# Altium schematic sheet Main Board-brushless_cmd-v2.0.0.SchDoc("Main Board-brushless_cmd-v2.0.0")
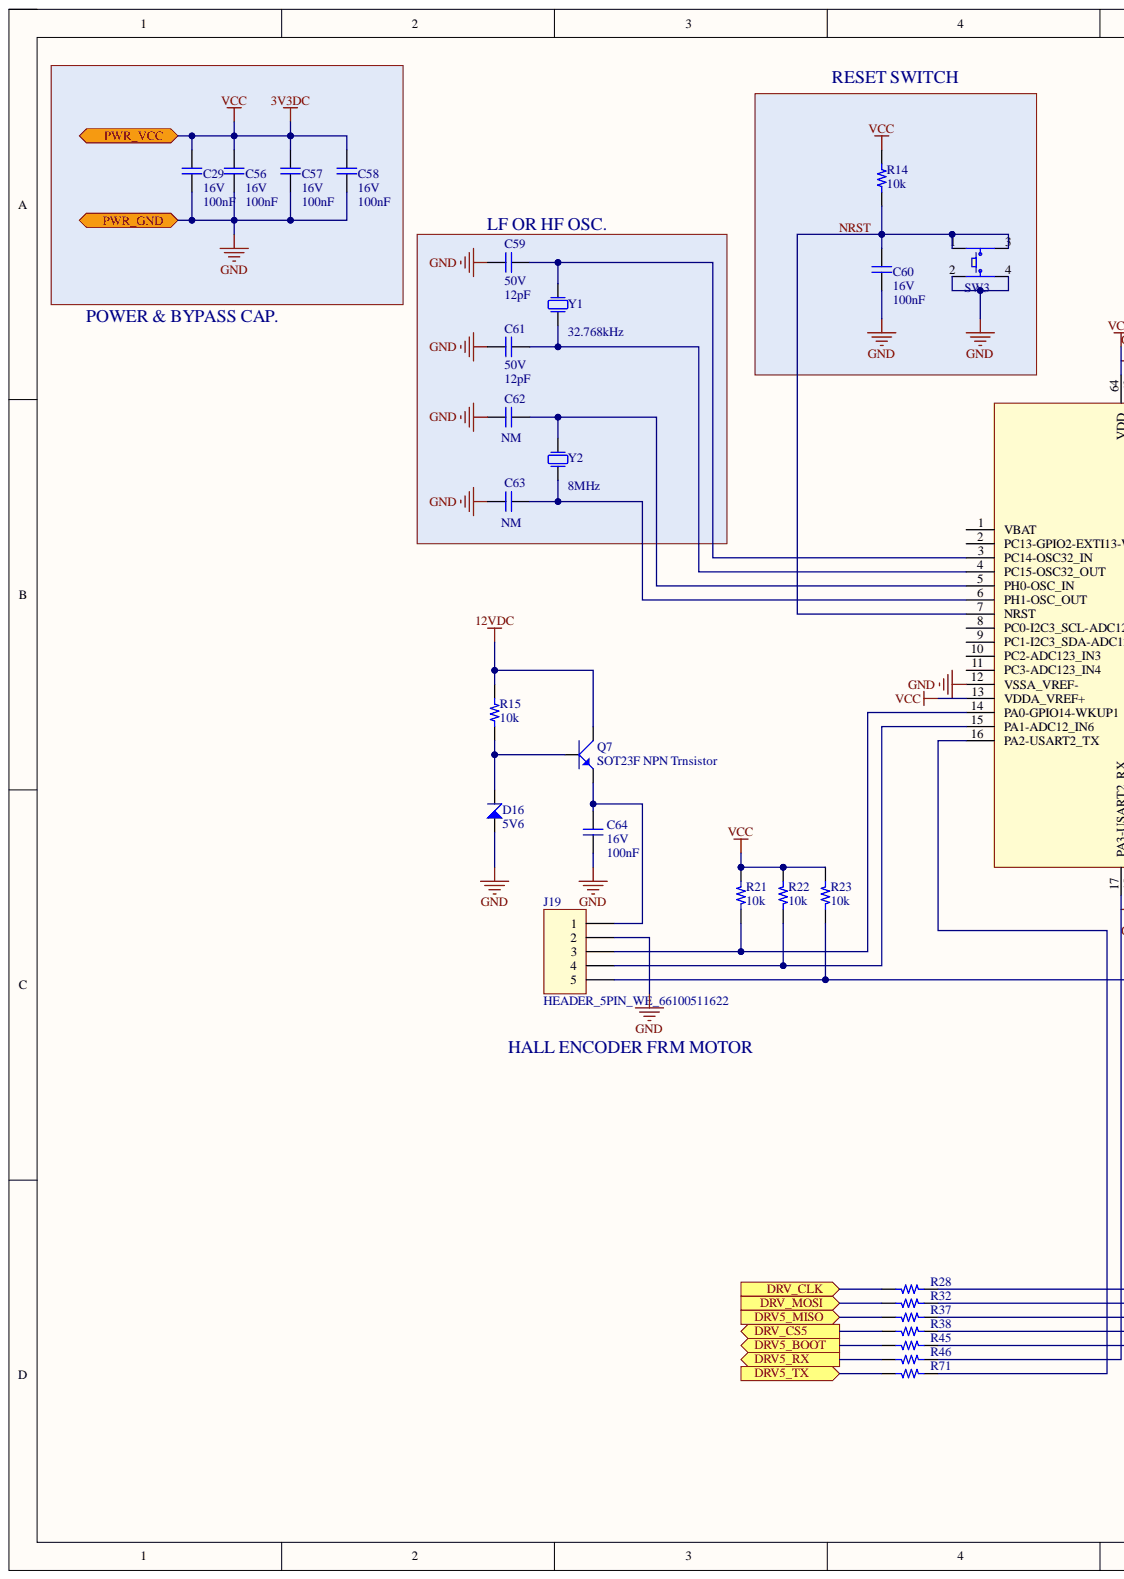
[diagram p0: Main Board-brushless_cmd-v2.0.0.SchDoc, left]
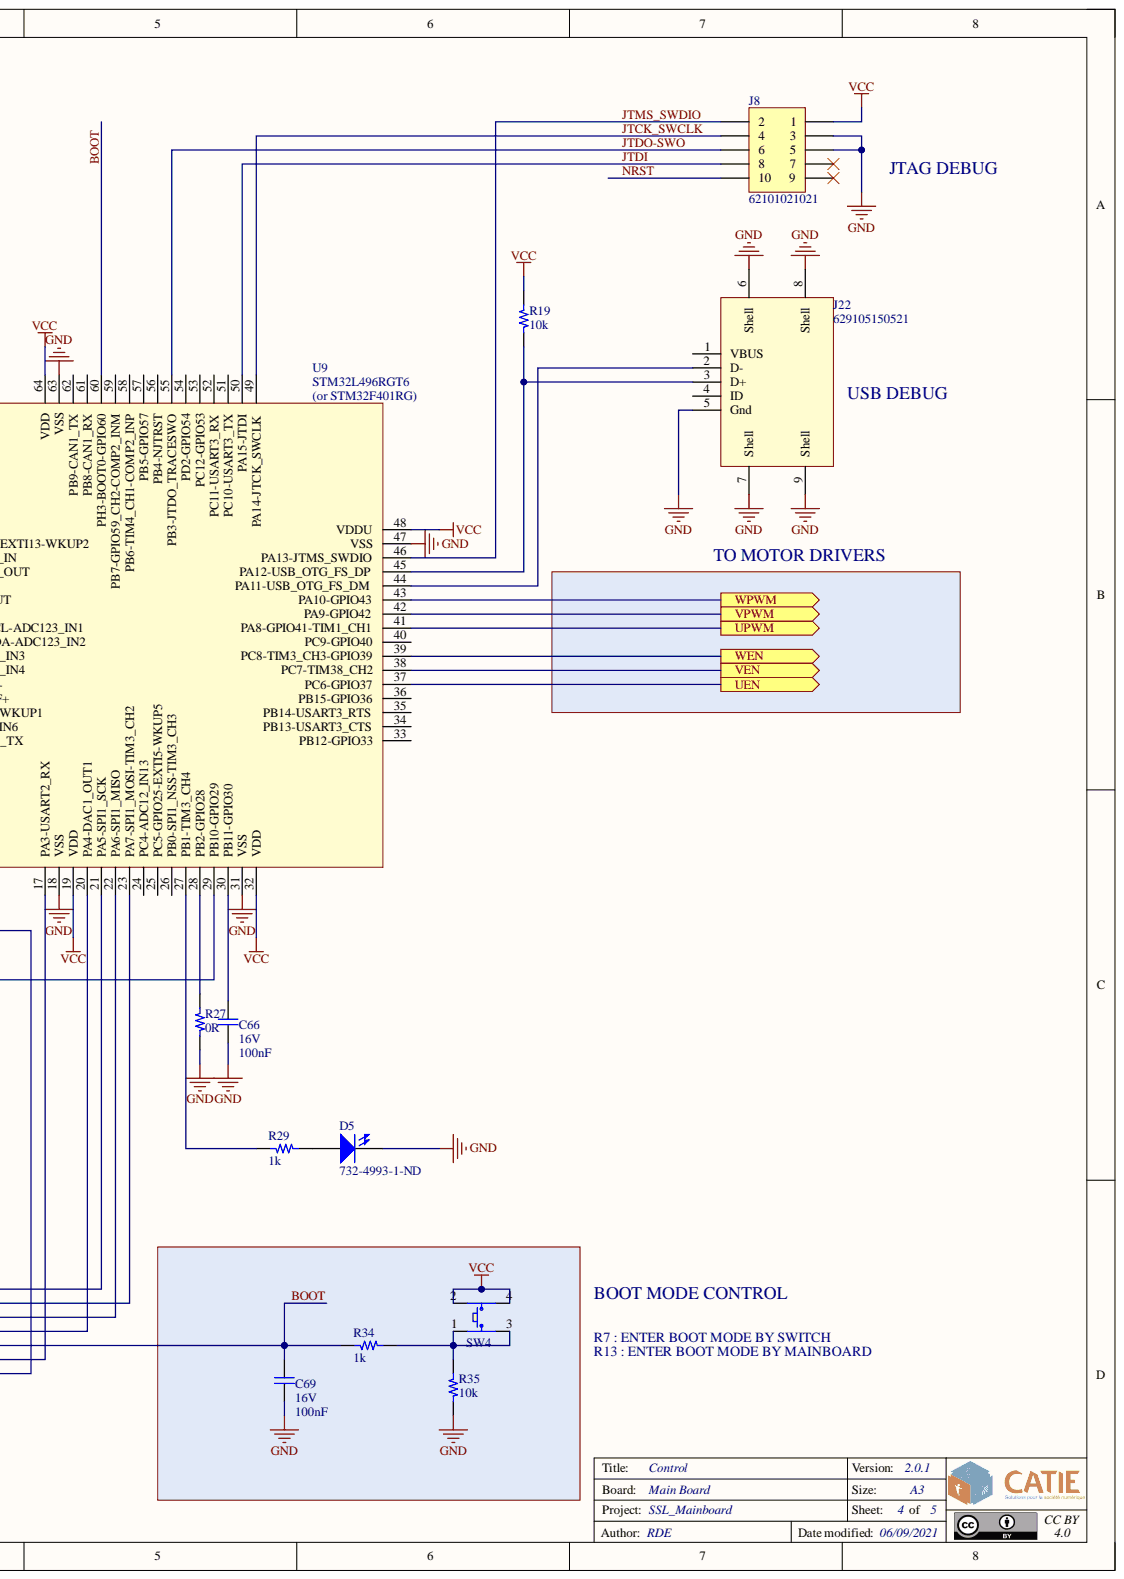
[diagram p1: Main Board-brushless_cmd-v2.0.0.SchDoc, right]

## Parts
C29  value 100nF  Rating: 16V  [CAP_CER_0603]  (hidden comment: CAP_CER_0603)
C56  value 100nF  Rating: 16V  [CAP_CER_0603]  (hidden comment: CAP_CER_0603)
C57  value 100nF  Rating: 16V  [CAP_CER_0603]  (hidden comment: CAP_CER_0603)
C58  value 100nF  Rating: 16V  [CAP_CER_0603]  (hidden comment: CAP_CER_0603)
C59  value 12pF  Rating: 50V  [CAP_CER_0603]  (hidden comment: CAP_CER_0603)
C60  value 100nF  Rating: 16V  [CAP_CER_0603]  (hidden comment: CAP_CER_0603)
C61  value 12pF  Rating: 50V  [CAP_CER_0603]  (hidden comment: CAP_CER_0603)
C62  value NM  [CAP_CER_0402]  (hidden comment: CAP_CER_0402)
C63  value NM  [CAP_CER_0402]  (hidden comment: CAP_CER_0402)
C64  value 100nF  Rating: 16V  [CAP_CER_0603]  (hidden comment: CAP_CER_0603)
C66  value 100nF  Rating: 16V  [CAP_CER_0603]  (hidden comment: CAP_CER_0603)
C69  value 100nF  Rating: 16V  [CAP_CER_0603]  (hidden comment: CAP_CER_0603)
D5  Supplier Part Number 1: 732-4993-1-ND  [DIODE_LED_1206_TOP]  (hidden comment: LED SMD 1206 Top view)
D16  value 5V6  [DIODE_ZENER_BZD27C-SERIES_DO219-AB]  (hidden comment: DIODE_ZENER_BZD27C-SERIES_DO219-AB)
J8  Reference: 62101021021  [HEADER_WURTH_62101021021_10PIN]  (hidden comment: HEADER_WURTH_62101021021)
J19  HEADER_5PIN_WE_66100511622  [66100511622]
J22  Reference: 629105150521  [HEADER_MICROUSB_629105150521]  (hidden comment: HEADER_MICROUSB_629105150521)
Q7  SOT23F NPN Trnsistor  [TR_SOT23]
R14  value 10k  [RES_0603]  (hidden comment: RES_0603)
R15  value 10k  [RES_0603]  (hidden comment: RES_0603)
R19  value 10k  [RES_0603]  (hidden comment: RES_0603)
R21  value 10k  [RES_0603]  (hidden comment: RES_0603)
R22  value 10k  [RES_0603]  (hidden comment: RES_0603)
R23  value 10k  [RES_0603]  (hidden comment: RES_0603)
R27  value 0R  [RES_0603]  (hidden comment: RES_0603)
R28  RES_0603  [RES_0603]  (value not drawn)
R29  value 1k  [RES_0603]  (hidden comment: RES_0603)
R32  RES_0603  [RES_0603]  (value not drawn)
R34  value 1k  [RES_0603]  (hidden comment: RES_0603)
R35  value 10k  [RES_0603]  (hidden comment: RES_0603)
R37  RES_0603  [RES_0603]  (value not drawn)
R38  RES_0603  [RES_0603]  (value not drawn)
R45  RES_0603  [RES_0603]  (value not drawn)
R46  RES_0603  [RES_0603]  (value not drawn)
R71  RES_0603  [RES_0603]  (value not drawn)
SW3  SW_TACT_MICRO_SIDE_WE_434331045822  [SW_TACT_MICRO_SIDE_WE_434331045822]  (value not drawn)
SW4  SW_TACT_MICRO_SIDE_WE_434331045822  [SW_TACT_MICRO_SIDE_WE_434331045822]  (value not drawn)
U9  Reference: STM32L496RGT6  [IC_STM32_LQFP64]  (hidden comment: IC_STM32L496RXTX_LQFP64)
Y1  value 32.768kHz  [XTAL_3.2x1.5]  (hidden comment: XTAL SMD 2-pin)
Y2  value 8MHz  [XTAL_3.2x1.5]  (hidden comment: XTAL SMD 2-pin)

## Ports
DRV5_BOOT, DRV5_MISO, DRV5_RX, DRV5_TX, DRV_CLK, DRV_CS5, DRV_MOSI, PWR_GND, PWR_VCC, UEN, UPWM, VEN, VPWM, WEN, WPWM

## Nets
12VDC: Q7.3 R15.2
3V3DC: C29.2 C56.2 C57.2 C58.2 J8.1 R14.2 R19.1 R21.1 R22.1 R23.1 SW4.2 SW4.4 U9.13(VDDA_VREF+) U9.19(VDD) U9.32(VDD) U9.48(VDDU) U9.64(VDD) ; port PWR_VCC
BOOT: C69.1 R34.1 R45.2 U9.60(PH3-BOOT0-GPIO60)
DRV5_BOOT: R45.1 ; port DRV5_BOOT
DRV5_MISO: R37.1 ; port DRV5_MISO
DRV5_RX: R46.1 ; port DRV5_RX
DRV5_TX: R71.1 ; port DRV5_TX
DRV_CLK: R28.1 ; port DRV_CLK
DRV_CS5: R38.1 ; port DRV_CS5
DRV_MOSI: R32.1 ; port DRV_MOSI
GND: C29.1 C56.1 C57.1 C58.1 C59.1 C60.2 C61.1 C62.1 C63.1 C64.1 C66.1 C69.2 D5.1 D16.2 J8.3 J8.5 J19.2 J22.5(Gnd) J22.6(Shell) J22.7(Shell) J22.8(Shell) J22.9(Shell) R27.1 R35.1 SW3.2 SW3.4 U9.12(VSSA_VREF-) U9.18(VSS) U9.31(VSS) U9.47(VSS) U9.63(VSS) ; port PWR_GND
JTCK_SWCLK: J8.4 U9.49(PA14-JTCK_SWCLK)
JTDI: J8.8 U9.50(PA15-JTDI)
JTDO-SWO: J8.6 U9.55(PB3-JTDO_TRACESWO)
JTMS_SWDIO: J8.2 U9.46(PA13-JTMS_SWDIO)
NRST: C60.1 J8.10 R14.1 SW3.1 SW3.3 U9.7(NRST)
UEN: U9.37(PC6-GPIO37) ; port UEN
UPWM: U9.41(PA8-GPIO41-TIM1_CH1) ; port UPWM
VEN: U9.38(PC7-TIM38_CH2) ; port VEN
VPWM: U9.42(PA9-GPIO42) ; port VPWM
WEN: U9.39(PC8-TIM3_CH3-GPIO39) ; port WEN
WPWM: U9.43(PA10-GPIO43) ; port WPWM
NetC59_2: C59.2 U9.3(PC14-OSC32_IN) Y1.2
NetC61_2: C61.2 U9.4(PC15-OSC32_OUT) Y1.1
NetC62_2: C62.2 U9.5(PH0-OSC_IN) Y2.2
NetC63_2: C63.2 U9.6(PH1-OSC_OUT) Y2.1
NetC64_2: C64.2 J19.1 Q7.2
NetC66_2: C66.2 U9.30(PB11-GPIO30)
NetD5_2: D5.2 R29.2
NetD16_1: D16.1 Q7.1 R15.1
NetJ19_3: J19.3 R21.2 U9.14(PA0-GPIO14-WKUP1)
NetJ19_4: J19.4 R22.2 U9.15(PA1-ADC12_IN6)
NetJ19_5: J19.5 R23.2 U9.29(PB10-GPIO29)
NetJ22_2: J22.2(D-) U9.44(PA11-USB_OTG_FS_DM)
NetJ22_3: J22.3(D+) R19.2 U9.45(PA12-USB_OTG_FS_DP)
NetR27_2: R27.2 U9.28(PB2-GPIO28)
NetR28_2: R28.2 U9.21(PA5-SPI1_SCK)
NetR29_1: R29.1 U9.27(PB1-TIM3_CH4)
NetR32_2: R32.2 U9.23(PA7-SPI1_MOSI-TIM3_CH2)
NetR34_2: R34.2 R35.2 SW4.1 SW4.3
NetR37_2: R37.2 U9.22(PA6-SPI1_MISO)
NetR38_2: R38.2 U9.20(PA4-DAC1_OUT1)
NetR46_2: R46.2 U9.17(PA3-USART2_RX)
NetR71_2: R71.2 U9.16(PA2-USART2_TX)

Not connected: J8 7 9; J22 1(VBUS) 4(ID); U9 1(VBAT) 2(PC13-GPIO2-EXTI13-WKUP2) 8(PC0-I2C3_SCL-ADC123_IN1) 9(PC1-I2C3_SDA-ADC123_IN2) 10(PC2-ADC123_IN3) 11(PC3-ADC123_IN4) 24(PC4-ADC12_IN13) 25(PC5-GPIO25-EXTI5-WKUP5) 26(PB0-SPI1_NSS-TIM3_CH3) 33(PB12-GPIO33) 34(PB13-USART3_CTS) 35(PB14-USART3_RTS) 36(PB15-GPIO36) 40(PC9-GPIO40) 51(PC10-USART3_TX) 52(PC11-USART3_RX) 53(PC12-GPIO53) 54(PD2-GPIO54) 56(PB4-NJTRST) 57(PB5-GPIO57) 58(PB6-TIM4_CH1-COMP2_INP) 59(PB7-GPIO59_CH2-COMP2_INM) 61(PB8-CAN1_RX) 62(PB9-CAN1_TX)

## Library data of the parts
C29 C56-C58 C60 C64 C66 C69 = CAP_CER_0603: Capacitor ceramics SMD 0603; Manufacturer: Samsung; Ceram: X7R; Reference: CL10A104KA8NNNC; Tol: 10%; Case: 0603; Component Kind: Standard; Library Name: Schematic_Components.SchLib; Mount: Mnt; Technology: CMS; Type: Capacitor
C59 C61 = CAP_CER_0603: Capacitor ceramics SMD 0603; Manufacturer: Samsung; Ceram: NPO; Reference: CL10C120JB8NNNC; Tol: 10%; Case: 0603; Component Kind: Standard; Library Name: Schematic_Components.SchLib; Mount: Mnt; Technology: CMS; Type: Capacitor
C62 = CAP_CER_0402: Capacitor ceramics SMD 0402; Ceram: X7R; Mount: DNP; Rating: 35V; Tol: 10%; Case: 0402; Component Kind: Standard; Library Name: Schematic_Components.SchLib; Technology: CMS; Type: Capacitor
C63 = CAP_CER_0402: Capacitor ceramics SMD 0402; Ceram: X7R; Mount: DNP; Tol: 10%; Case: 0402; Component Kind: Standard; Library Name: Schematic_Components.SchLib; Technology: CMS; Type: Capacitor
D5 = DIODE_LED_1206_TOP: Manufacturer: Wurth Elektronik; Colour: Bright Green; Rating: 30mA; Reference: 150120VS75000; Value: 2V; Case: 1206; Component Kind: Standard; Description: LED SMD 1206 Top view; Library Name: Schematic_Components.SchLib; Mount: Mnt; Supplier 1: Digi-Key; Technology: CMS; Type: Diode_LED
D16 = DIODE_ZENER_BZD27C-SERIES_DO219-AB: Diode Zener BZD27C-series DO219-AB case; Manufacturer: Vishay; Rating: 800mW; Reference: BZD27C15P-E3-08; Tol: 1%; Case: SOD132F; Component Kind: Standard; Library Name: Schlib1.SchLib; Mount: Mnt; Supplier 1: Digi-Key; Supplier Part Number 1: BZD27C15P-E3-08CT-ND; Technology: CMS; Type: Diode
J8 = HEADER_WURTH_62101021021: Header WR-PHD 1.27mm pitch SMT Straight Dual-10Pin; Manufacturer: Wurth; Mount: DNP; Rating: 1A, 100V(AC); Value: 20mR; Component Kind: Standard; Library Name: Schlib1.SchLib; Technology: CMS; Type: Header
J19 = HEADER_5PIN_WE_66100511622: WR-WTB 2.54 mm Intercom Male Horizontal Shrouded Header, 5p; Mount: DNP; Component Kind: Standard; Library Name: Schlib1.SchLib
J22 = HEADER_MICROUSB_629105150521: Header microUSB Type-B SMD; Manufacturer: Wurth Elektronik; Rating: 3A; Value: USB - Type B; Component Kind: Standard; Library Name: Schematic_Components.SchLib; Mount: Mnt; Supplier 1: Digi-Key; Supplier Part Number 1: 732-5960-1-ND; Technology: CMS; Type: Header
Q7 = Q_NPN: General purpose bipolar NPN transistor; Manufacturer: Diode Inc; Reference: ZXTN19100CFF; Case: SOT-23; Component Kind: Standard; Library Name: Schlib1.SchLib; Mount: Mnt; Technology: CMS; Type: Transistor
R14 R15 R19 R21-R23 R35 = RES_0603: Resistor SMD 0603; Manufacturer: Yageo; Rating: 0.1W; Reference: RC0603FR-0710KL; Tol: 1%; Case: 0603; Component Kind: Standard; Library Name: Schematic_Components.SchLib; Mount: Mnt; Technology: CMS; Type: Resistor
R27 = RES_0603: Resistor SMD 0603; Manufacturer: Yageo; Rating: 1/10W; Reference: RC0603JR-070RL; Case: 0603; Component Kind: Standard; Library Name: Schematic_Components.SchLib; Mount: Mnt; Technology: CMS; Type: Resistor
R28 R32 R37 R38 R46 R71 = RES_0603: Resistor SMD 0603; Manufacturer: Yageo; Rating: 0.1W; Reference: RC0603FR-0710RL; Tol: 1%; Value: 10R; Case: 0603; Component Kind: Standard; Library Name: Schematic_Components.SchLib; Mount: Mnt; Technology: CMS; Type: Resistor
R29 R34 = RES_0603: Resistor SMD 0603; Manufacturer: Yageo; Rating: 0.1W; Reference: RC0603FR-071KL; Case: 0603; Component Kind: Standard; Library Name: Schematic_Components.SchLib; Mount: Mnt; Technology: CMS; Type: Resistor
R45 = RES_0603: Resistor SMD 0603; Mount: DNP; Case: 0603; Component Kind: Standard; Library Name: Schematic_Components.SchLib; Technology: CMS; Type: Resistor
SW3 SW4 = SW_TACT_MICRO_SIDE_WE_434331045822: Switch tactile smd Side push WS-TASU no Boss; Manufacturer: Wurth Elektronik; Rating: 12V / 50mA; Component Kind: Standard; Library Name: Schematic_Components.SchLib; Mount: Mnt; Reference: 434331045822; Supplier 1: Digi-Key; Supplier Part Number 1: 732-7055-1-ND; Technology: CMS; Type: Switch
U9 = IC_STM32L496RXTX_LQFP64: IC microcontroller STM32L496 LQFP64; Manufacturer: ST Microelectronics; Case: LQFP64; Component Kind: Standard; Library Name: Schematic_Components.SchLib; Mount: Mnt; Supplier 1: Digi-Key; Supplier Part Number 1: 497-17101-ND; Technology: CMS; Type: IC
Y1 Y2 = XTAL_SMD_2-pin: Quartz SMD 3.2x1.5mm case; Manufacturer: Epson; Rating: 0.5µW; Reference: FC-135 32.768KHz 7pF +/-20ppm; Tol: 20ppm; Case: 3.2x1.5mm; Component Kind: Standard; Library Name: Schematic_Components.SchLib; Mount: Mnt; Supplier 1: Digi-Key; Supplier Part Number 1: SER4086CT-ND; Technology: CMS; Type: XTAL
